# Altium schematic sheet PZEM-004T.SchDoc(PZEM-004T)
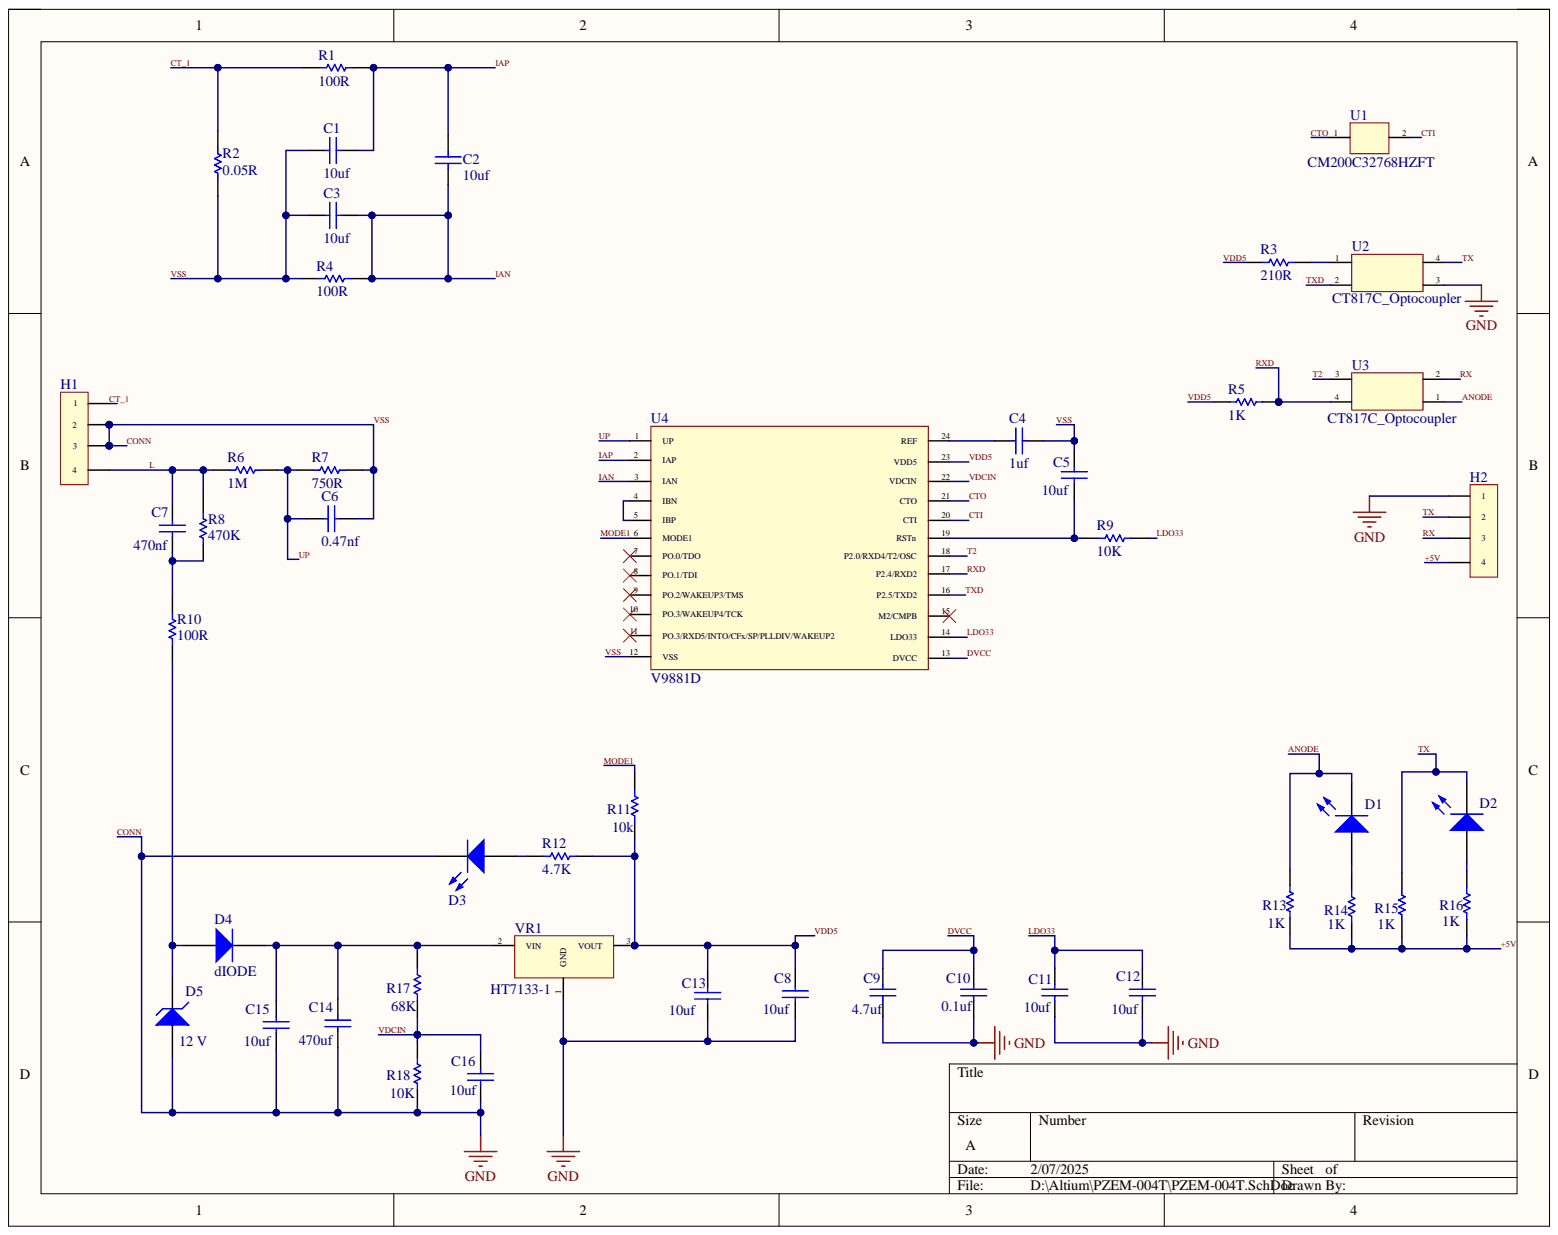
[diagram p0: PZEM-004T.SchDoc]

## Parts
C1  10uf  [CAPC1005X55N]
C2  10uf  [CAPC1005X55N]
C3  10uf  [CAPC1005X55N]
C4  1uf  [CAPC1005X55N]
C5  10uf  [CAPC1005X55N]
C6  0.47nf  [CAPRR1250W60L1530T500H1150]
C7  470nf  [CAPC1005X55N]
C8  10uf  [CAPC1005X55N]
C9  4.7uf  [CAPC1005X55N]
C10  0.1uf  [CAPC1005X55N]
C11  10uf  [CAPC1005X55N]
C12  10uf  [CAPC1005X55N]
C13  10uf  [CAPC1005X55N]
C14  470uf  [CAPC1005X55N]
C15  10uf  [CAPC1005X55N]
C16  10uf  [CAPC1005X55N]
D1  LED_  [WL-SMCW_0603_150060xx8x000]  (value not drawn)
D2  LED_  [WL-SMCW_0603_150060xx8x000]  (value not drawn)
D3  LED_  [WL-SMCW_0603_150060xx8x000]  (value not drawn)
D4  dIODE
D5  12 V  [SMA_DIO-L]
H1  HEADER X 4  [4 Position Wire to Board Terminal Block Horizontal]  (value not drawn)
H2  HEADER X 4  [4 Position Wire to Board Terminal Block Horizontal]  (value not drawn)
R1  100R  [RESISTOR]
R2  0.05R  [RESISTOR]
R3  210R  [RESISTOR]
R4  100R  [RESISTOR]
R5  1K  [RESISTOR]
R6  1M  [RESAD700W45L350D185]
R7  750R  [RESISTOR]
R8  470K  [RESISTOR]
R9  10K  [RESISTOR]
R10  100R  [RESISTOR]
R11  10k  [RESISTOR]
R12  4.7K  [RESISTOR]
R13  1K  [RESISTOR]
R14  1K  [RESISTOR]
R15  1K  [RESISTOR]
R16  1K  [RESISTOR]
R17  68K  [RESISTOR]
R18  10K  [RESISTOR]
U1  CM200C32768HZFT  [XTAL_CM8V-T1A-32.768KHZ-12.5PF-20PPM-TA-QA]
U2  CT817C_Optocoupler  [SOP4]
U3  CT817C_Optocoupler  [SOP4]
U4  V9881D  [SOP24 - duplicate]
VR1  HT7133-1  [SOP3]

## Nets
+5V: H2.4 R13.1 R14.1 R15.1 R16.1
ANODE: D1.2 R13.2 U3.1
CONN: C1.2 C3.2 C4.2 C5.2 C6.1 C8.1 C9.1 C10.1 C11.1 C12.1 C13.1 C14.1 C15.1 C16.1 D3.2 D5.1 H1.2 H1.3 H2.1 R2.2 R4.2 R7.2 R18.1 U2.3 U4.12(VSS) VR1.1
CT_1: H1.1 R1.2 R2.1
CTI: U1.2 U4.20(CTI)
CTO: U1.1 U4.21(CTO)
DVCC: C9.2 C10.2 U4.13(DVCC)
IAN: C2.1 C3.1 R4.1 U4.3(IAN)
IAP: C1.1 C2.2 R1.1 U4.2(IAP)
L: C7.2 H1.4 R6.1 R8.1
LDO33: C11.2 C12.2 R9.2 U4.14(LDO33)
MODE1: R11.2 U4.6(MODE1)
RX: H2.3 U3.2
RXD: R5.1 U3.4 U4.17(P2.4/RXD2)
T2: U3.3 U4.18(P2.0/RXD4/T2/OSC)
TX: D2.2 H2.2 R15.2 U2.4
TXD: U2.2 U4.16(P2.5/TXD2)
UP: C6.2 R6.2 R7.1 U4.1(UP)
VDCIN: C16.2 R17.1 R18.2 U4.22(VDCIN)
VDD5: C8.2 C13.2 R3.2 R5.2 R11.1 R12.1 U4.23(VDD5) VR1.3
NetC4_1: C4.1 U4.24(REF)
NetC5_1: C5.1 R9.1 U4.19(RSTn)
NetC7_1: C7.1 R8.2 R10.1
NetC14_2: C14.2 C15.2 D4.2 R17.2 VR1.2
NetD1_1: D1.1 R14.2
NetD2_1: D2.1 R16.2
NetD3_1: D3.1 R12.2
NetD4_1: D4.1 D5.2 R10.2
NetR3_1: R3.1 U2.1
NetU4_4: U4.4(IBN) U4.5(IBP)

Not connected: U4 7(PO.0/TDO) 8(PO.1/TDI) 9(PO.2/WAKEUP3/TMS) 10(PO.3/WAKEUP4/TCK) 11(PO.3/RXD5/INTO/CFx/SP/PLLDIV/WAKEUP2) 15(M2/CMPB)

## Library data of the parts
C1-C16 = capacitor: CAP CER 10UF 6.3V X5R 0402; Manufacturer: Murata Electronics; Manufacturer Product Number: GRM155R60J106ME47D; Component Kind: Standard; Supplier: DigiKey; Supplier part number: 490-13239-1-ND; Library Name: PZEM-004T.SchLib
D1-D3 = LED_: LED GREEN CLEAR 0805 SMD; Manufacturer: Lumex Opto/Components Inc.; Manufacturer Product Number: SML-LX0805UPGC-TR; Component Kind: Standard; supplier: DigiKey; supplier product number: 67-1640-2-ND; Library Name: PZEM-004T.SchLib
D5 = ZENER: Zener Diode 12 V 1 W ±5% Surface Mount SMA; Manufacturer: Diodes Incorporated; Manufacturer Product Number: SMAZ12-13-F; Component Kind: Standard; supplier: DigiKey; supplier Product Number: SMAZ12-FDICT-ND; Library Name: PZEM-004T.SchLib
R1-R18 = Resistor: RES 10K OHM 5% 1/4W 1206; Manufacturer: TE Connectivity Passive Product; Manufacturer Product Number: CRGCQ1206J10K; Component Kind: Standard; Supplier: DigiKey; Supplier part number: A130183CT-ND; Library Name: PZEM-004T.SchLib
U1 = CM200C32768HZFT: 32.768 kHz ±5ppm Crystal 12.5pF 50 kOhms 4-SOJ, 5.50mm pitch; Manufacturer: Citizen Finedevice Co Ltd; Component Kind: Standard; Manufacturer Product Number: CM200C32768HZFT; supplier: DigiKey; supplier Product Number: 300-8742-1-ND; Library Name: PZEM-004T.SchLib
U2 U3 = CT817C_Optocoupler: 35V 5kV 50mA 100mV@1mA,20mA 1 6V 1.24V DC SOP-4-2.54mm Transistor, Photovoltaic Output Optoisolators ROHS; Manufacturer: CT Micro International; Manufacturer product number: CT817C(SL)(T1)-H; Component Kind: Standard; Library Name: PZEM-004T.SchLib
U4 = V9881D: V9881D SSOP24 Three-phase Multifunctional Metering Chip Energy Metering V9881; Component Kind: Standard; Library Name: PZEM-004T.SchLib
VR1 = HT7133-1: Linear Voltage Regulator IC Positive Fixed 1 Output 100mA SOT-89-3; Manufacturer: UMW; Component Kind: Standard; Manufacturer Product Number: HT7133-1; supplier: DigiKey; supplier product number: 4518-HT7133-1CT-ND; Library Name: PZEM-004T.SchLib
D4 = dIODE: Component Kind: Standard; Library Name: PZEM-004T.SchLib
H1 H2 = HEADER X 4: Component Kind: Standard; Library Name: PZEM-004T.SchLib
